# Altium schematic sheet 04_gap_interface.SchDoc(04_gap_interface)
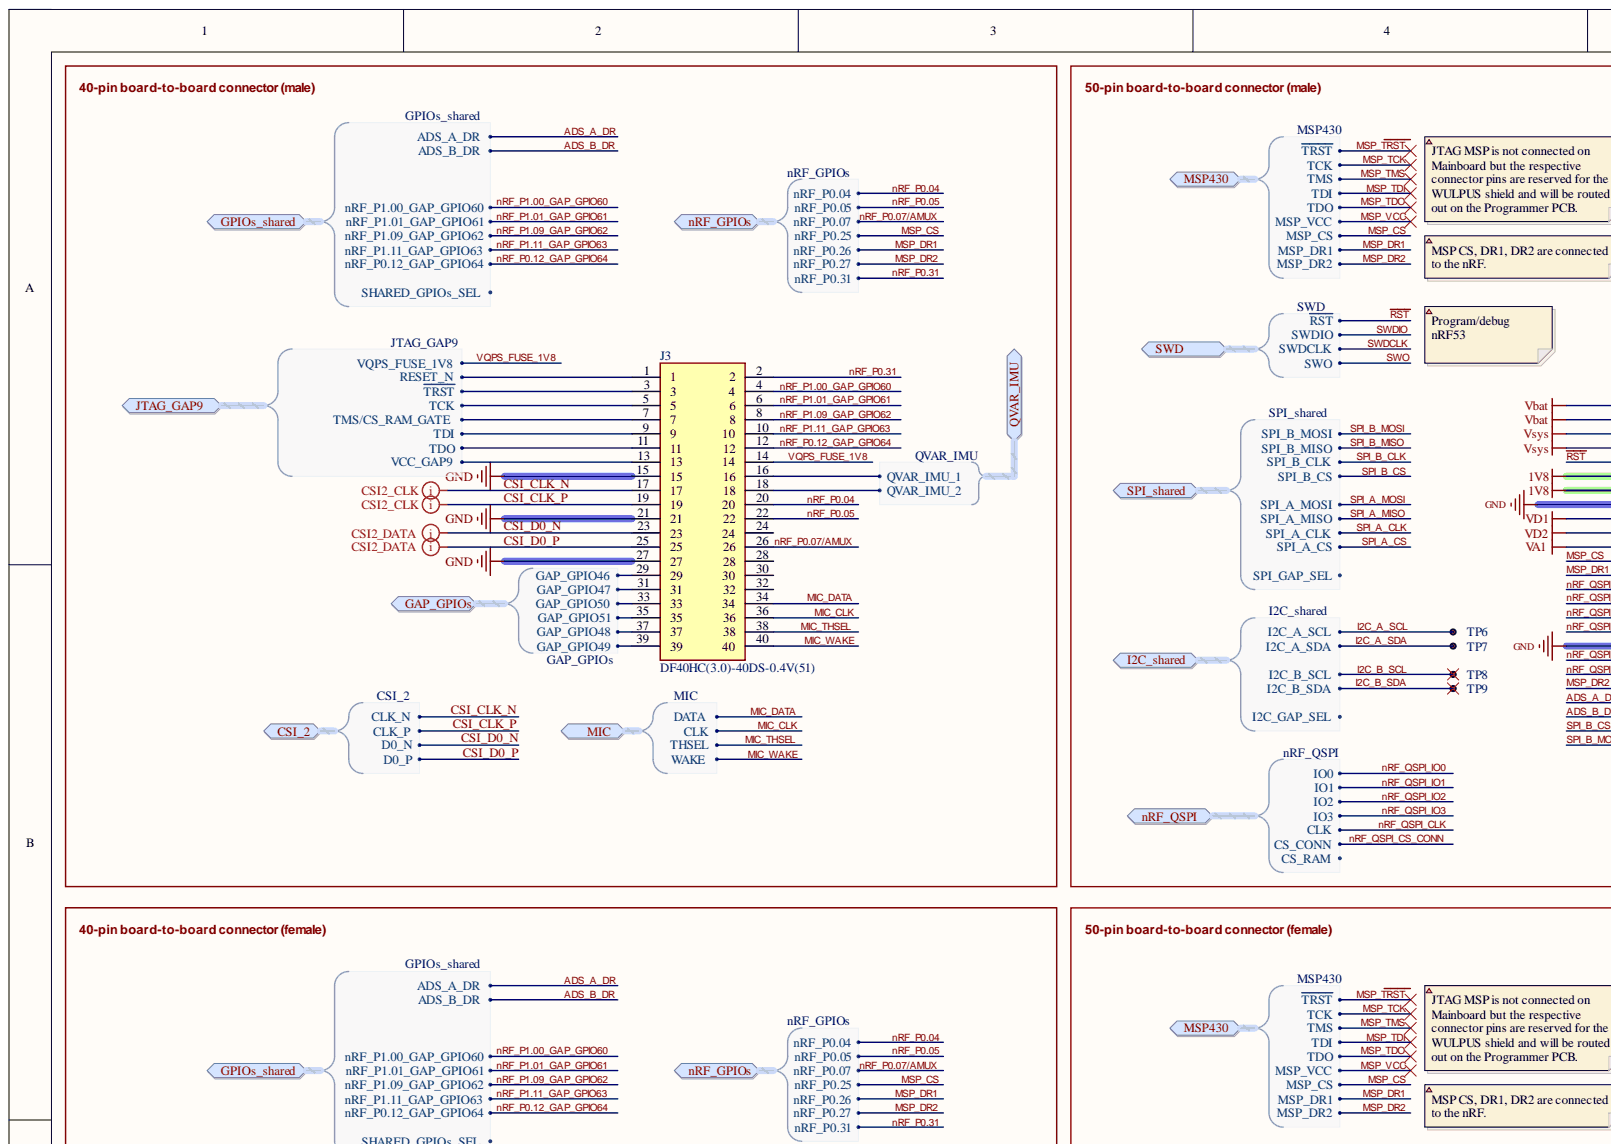
[diagram p0: 04_gap_interface.SchDoc, top left]
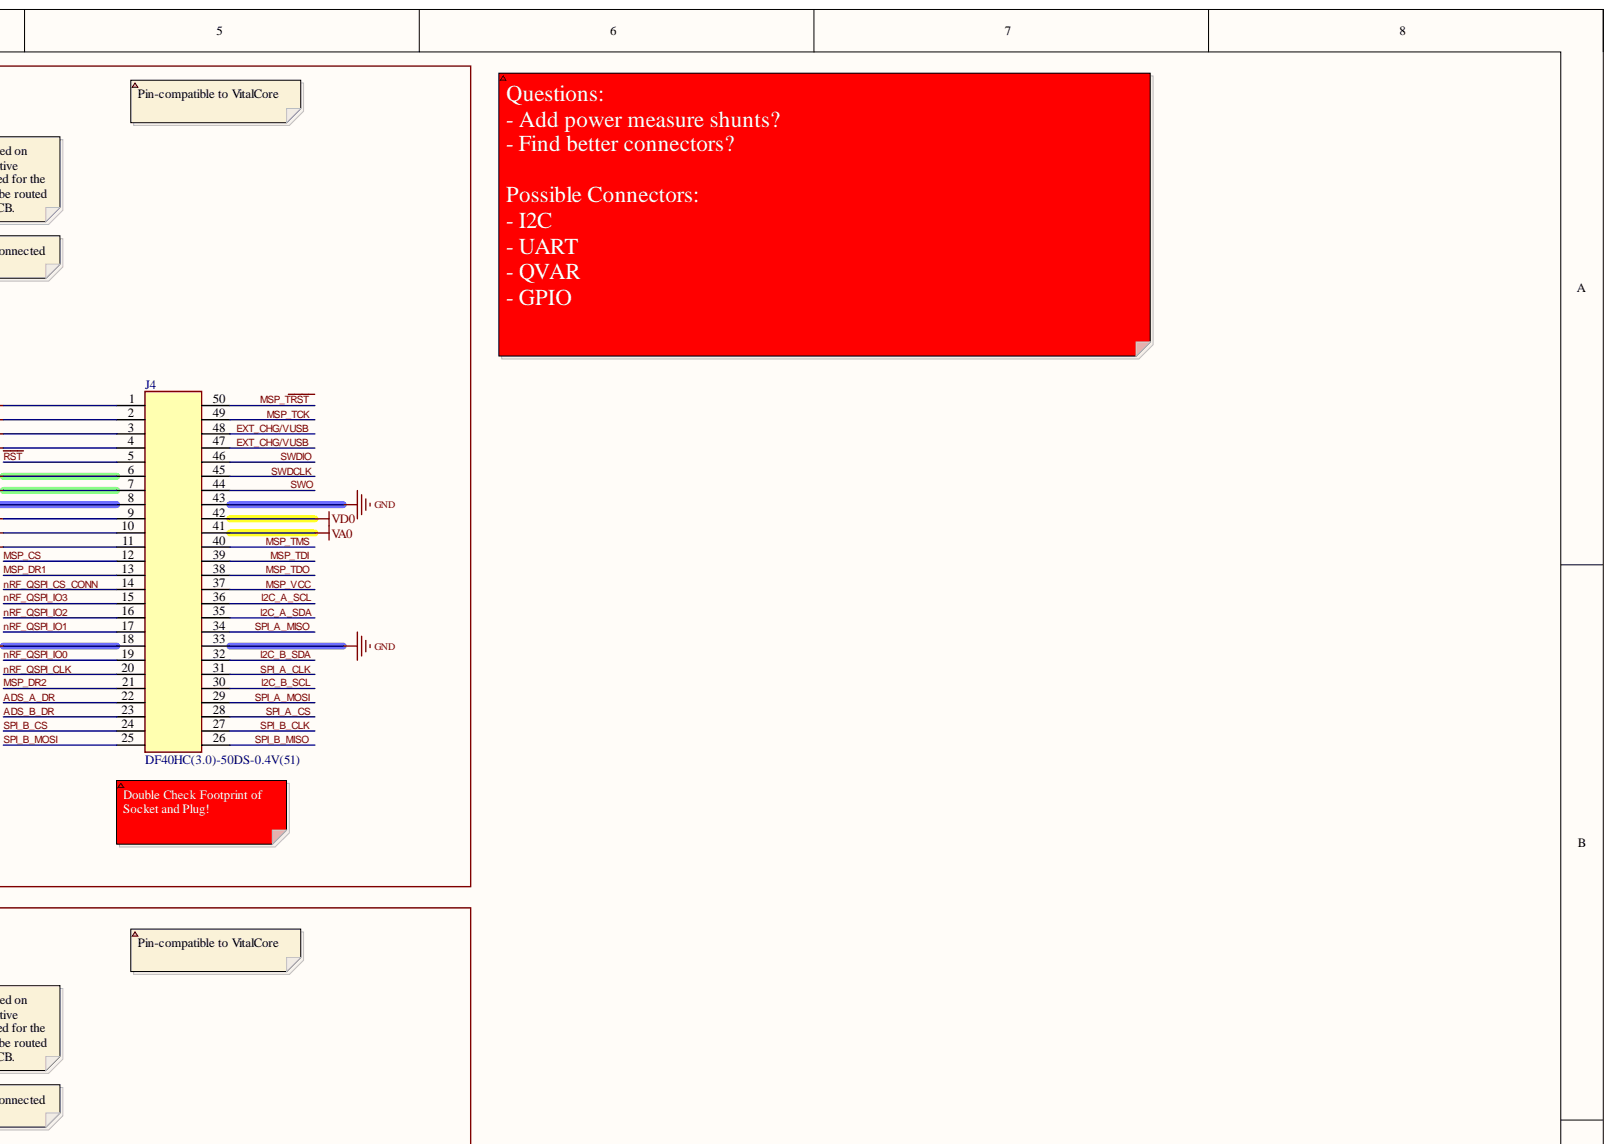
[diagram p1: 04_gap_interface.SchDoc, top right]
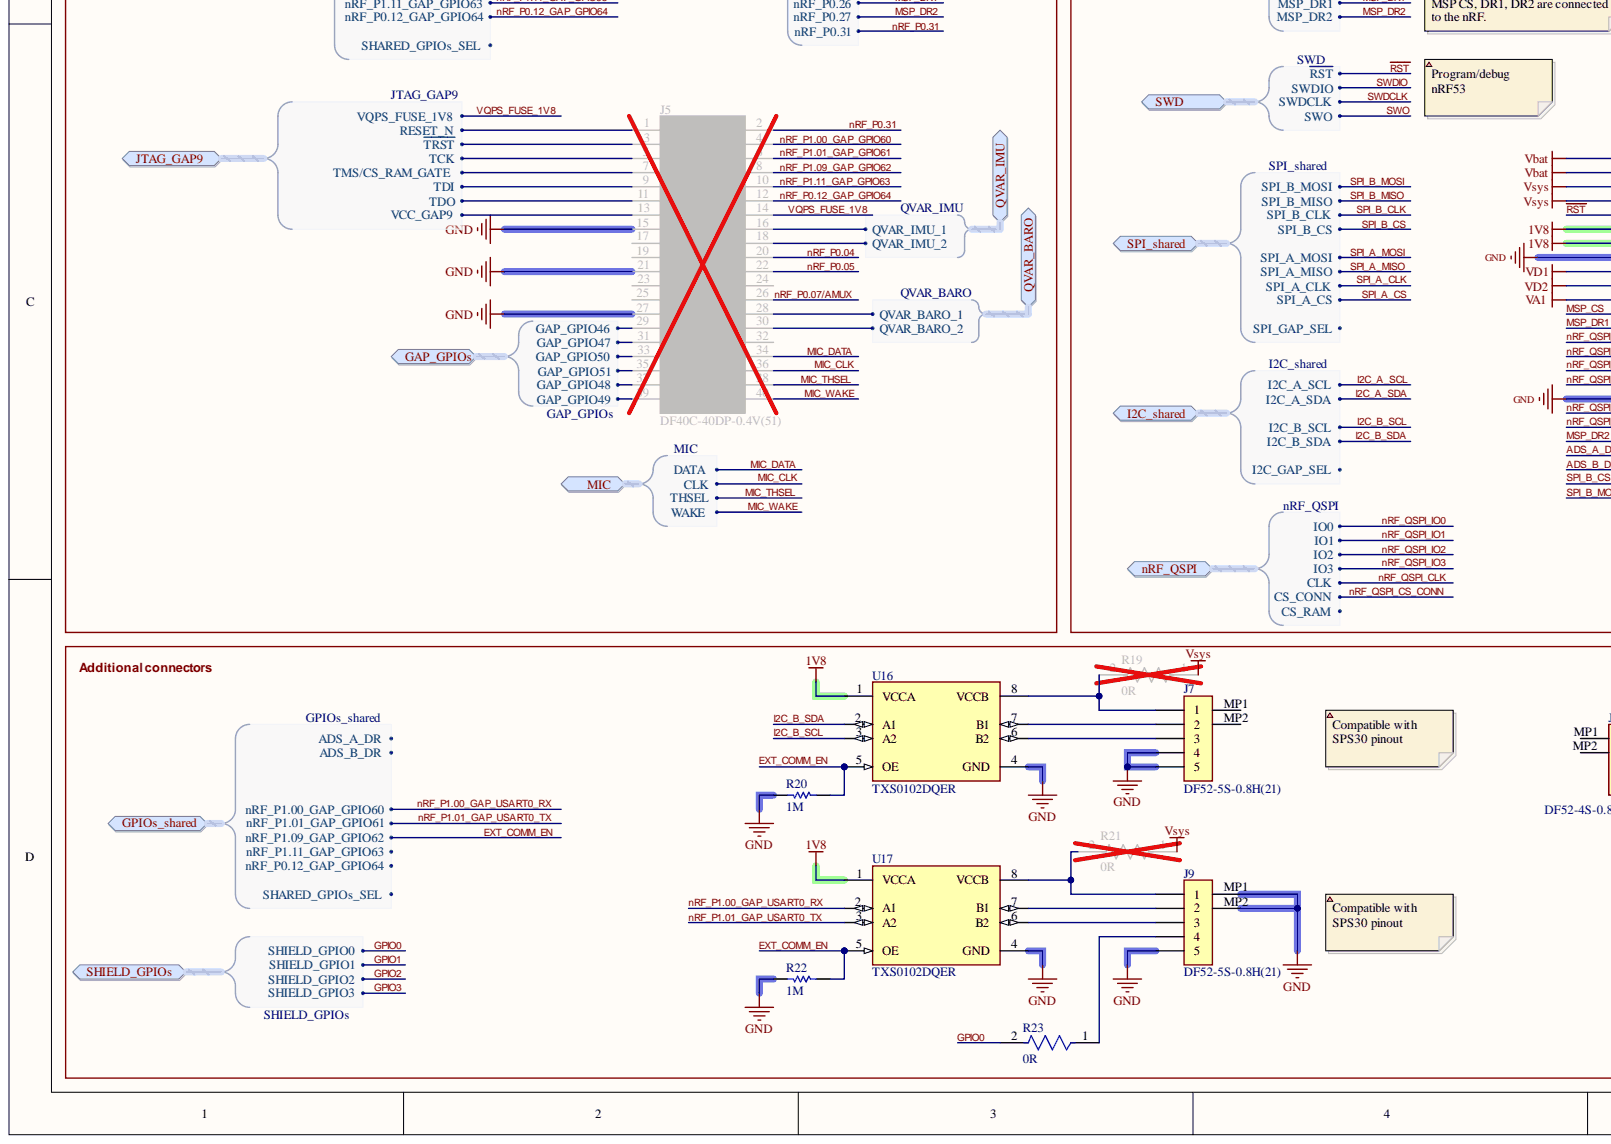
[diagram p2: 04_gap_interface.SchDoc, bottom left]
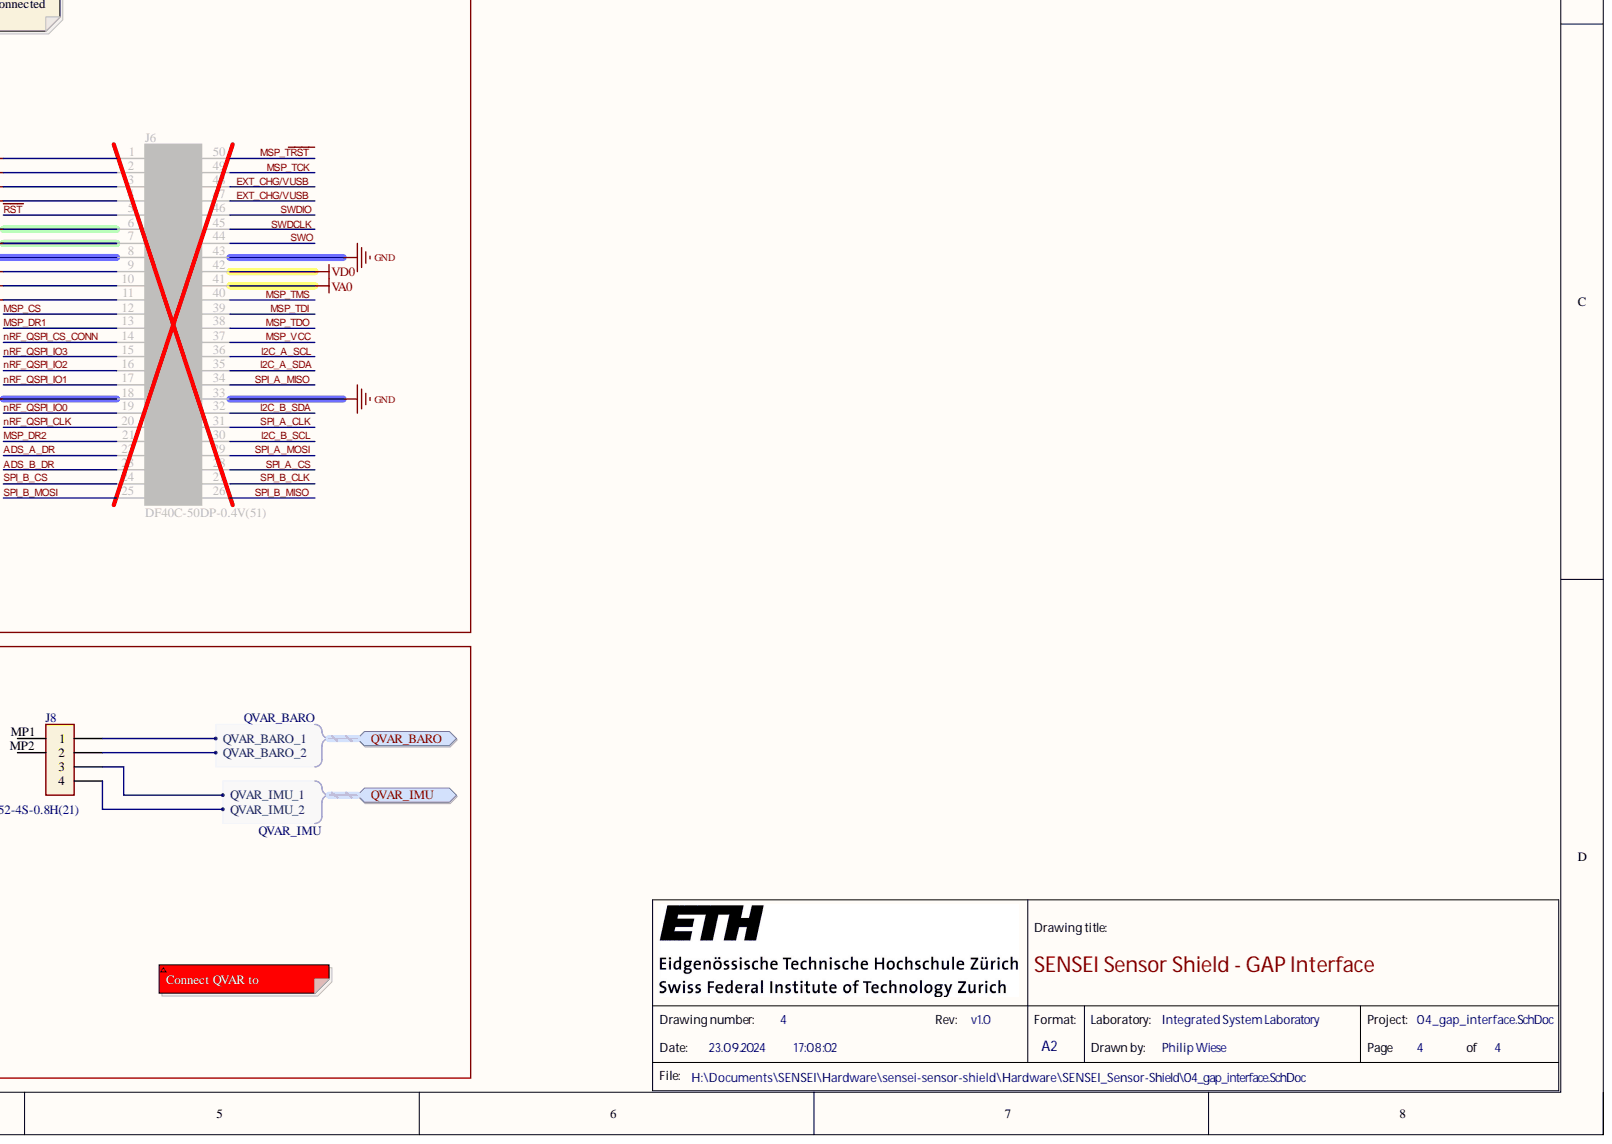
[diagram p3: 04_gap_interface.SchDoc, bottom right]

## Parts
J3  DF40HC(3.0)-40DS-0.4V(51)  [DF40HC3040DS04V51]
J4  DF40HC(3.0)-50DS-0.4V(51)  [DF40HC3050DS04V51]
J5  DF40C-40DP-0.4V(51)  [FP-DF40C-40DP-0_4V_51-MFG]
J6  DF40C-50DP-0.4V(51)  [CONN_COMBI_50P]
J7  DF52-5S-0.8H(21)  [DF525S08H21]
J8  DF52-4S-0.8H(21)  [DF524S08H21]
J9  DF52-5S-0.8H(21)  [DF525S08H21]
R19  Resistance: 0R  [FP-RMCF0402-IPC_A]  (hidden comment: RMCF0402ZT0R00)
R20  value 1M  Resistance: 1M  [RESC0201(0603)_L]  (hidden comment: ERJ-1GNJ105C)
R21  Resistance: 0R  [FP-RMCF0402-IPC_A]  (hidden comment: RMCF0402ZT0R00)
R22  value 1M  Resistance: 1M  [RESC0201(0603)_L]  (hidden comment: ERJ-1GNJ105C)
R23  Resistance: 0R  [FP-RMCF0402-IPC_A]  (hidden comment: RMCF0402ZT0R00)
TP6  Test Pad  [Pad-0.6mm]  (value not drawn)  (no BOM)
TP7  Test Pad  [Pad-0.6mm]  (value not drawn)  (no BOM)
TP8  Test Pad  [Pad-0.6mm]  (value not drawn)  (no BOM)
TP9  Test Pad  [Pad-0.6mm]  (value not drawn)  (no BOM)
U16  TXS0102DQER  [FP-DQE0008A-MFG]
U17  TXS0102DQER  [FP-DQE0008A-MFG]

## Ports
CSI_2, CSI_2.CLK_N, CSI_2.CLK_P, CSI_2.D0_N, CSI_2.D0_P, GAP_GPIOs, GAP_GPIOs.GAP_GPIO46, GAP_GPIOs.GAP_GPIO47, GAP_GPIOs.GAP_GPIO48, GAP_GPIOs.GAP_GPIO49, GAP_GPIOs.GAP_GPIO50, GAP_GPIOs.GAP_GPIO51, GPIOs_shared, GPIOs_shared.ADS_A_DR, GPIOs_shared.ADS_B_DR, GPIOs_shared.nRF_P0.12_GAP_GPIO64, GPIOs_shared.nRF_P1.00_GAP_GPIO60, GPIOs_shared.nRF_P1.01_GAP_GPIO61, GPIOs_shared.nRF_P1.09_GAP_GPIO62, GPIOs_shared.nRF_P1.11_GAP_GPIO63, GPIOs_shared.SHARED_GPIOs_SEL, I2C_shared, I2C_shared.I2C_A_SCL, I2C_shared.I2C_A_SDA, I2C_shared.I2C_B_SCL, I2C_shared.I2C_B_SDA, I2C_shared.I2C_GAP_SEL, JTAG_GAP9, JTAG_GAP9.RESET_N, JTAG_GAP9.TCK, JTAG_GAP9.TDI, JTAG_GAP9.TDO, JTAG_GAP9.TMS/CS_RAM_GATE, JTAG_GAP9.VCC_GAP9, JTAG_GAP9.VQPS_FUSE_1V8, JTAG_GAP9.~{TRST}, MIC, MIC.CLK, MIC.DATA, MIC.THSEL, MIC.WAKE, MSP430, MSP430.MSP_CS, MSP430.MSP_DR1, MSP430.MSP_DR2, MSP430.MSP_VCC, MSP430.TCK, MSP430.TDI, MSP430.TDO, MSP430.TMS, MSP430.~{TRST}, nRF_GPIOs, nRF_GPIOs.nRF_P0.04, nRF_GPIOs.nRF_P0.05, nRF_GPIOs.nRF_P0.07, nRF_GPIOs.nRF_P0.25, nRF_GPIOs.nRF_P0.26, nRF_GPIOs.nRF_P0.27, nRF_GPIOs.nRF_P0.31, nRF_QSPI, nRF_QSPI.CLK, nRF_QSPI.CS_CONN, nRF_QSPI.CS_RAM, nRF_QSPI.IO0, nRF_QSPI.IO1, nRF_QSPI.IO2, nRF_QSPI.IO3, QVAR_BARO, QVAR_BARO.QVAR_BARO_1, QVAR_BARO.QVAR_BARO_2, QVAR_IMU, QVAR_IMU.QVAR_IMU_1, QVAR_IMU.QVAR_IMU_2, SHIELD_GPIOs, SHIELD_GPIOs.SHIELD_GPIO0, SHIELD_GPIOs.SHIELD_GPIO1, SHIELD_GPIOs.SHIELD_GPIO2, SHIELD_GPIOs.SHIELD_GPIO3, SPI_shared, SPI_shared.SPI_A_CLK, SPI_shared.SPI_A_CS, SPI_shared.SPI_A_MISO, SPI_shared.SPI_A_MOSI, SPI_shared.SPI_B_CLK, SPI_shared.SPI_B_CS, SPI_shared.SPI_B_MISO, SPI_shared.SPI_B_MOSI, SPI_shared.SPI_GAP_SEL, SWD, SWD.SWDCLK, SWD.SWDIO, SWD.SWO, SWD.~{RST}

## Nets
1V8: J4.6 J4.7 J6.6 J6.7 U16.1(VCCA) U17.1(VCCA)
GND: J3.15 J3.21 J3.27 J4.8 J4.18 J4.33 J4.43 J5.15 J5.21 J5.27 J6.8 J6.18 J6.33 J6.43 J7.4 J7.5 J9.5 J9.MP1 J9.MP2 R20.2 R22.2 U16.4(GND) U17.4(GND)
VA0: J4.41 J6.41
VA1: J4.11 J6.11
Vbat: J4.1 J4.2 J6.1 J6.2
VD0: J4.42 J6.42
VD1: J4.9 J6.9
VD2: J4.10 J6.10
Vsys: J4.3 J4.4 J6.3 J6.4 R19.1 R21.1
ADS_A_DR: J4.22 J6.22 ; port GPIOs_shared
ADS_B_DR: J4.23 J6.23 ; port GPIOs_shared
CSI_CLK_N: J3.17 ; port CSI_2
CSI_CLK_P: J3.19 ; port CSI_2
CSI_D0_N: J3.23 ; port CSI_2
CSI_D0_P: J3.25 ; port CSI_2
EXT_CHG/VUSB: J4.47 J4.48 J6.47 J6.48
EXT_COMM_EN: J3.8 J5.8 R20.1 R22.1 U16.5(OE) U17.5(OE) ; port GPIOs_shared
GPIO0: R23.2 ; port SHIELD_GPIOs
I2C_A_SCL: J4.36 J6.36 TP6.1 ; port I2C_shared
I2C_A_SDA: J4.35 J6.35 TP7.1 ; port I2C_shared
I2C_B_SCL: J4.30 J6.30 TP8.1 U16.3(A2) ; port I2C_shared
I2C_B_SDA: J4.32 J6.32 TP9.1 U16.2(A1) ; port I2C_shared
MIC_CLK: J3.36 J5.36 ; port MIC
MIC_DATA: J3.34 J5.34 ; port MIC
MIC_THSEL: J3.38 J5.38 ; port MIC
MIC_WAKE: J3.40 J5.40 ; port MIC
MSP_CS: J4.12 J6.12 ; port MSP430, port nRF_GPIOs
MSP_DR1: J4.13 J6.13 ; port MSP430, port nRF_GPIOs
MSP_DR2: J4.21 J6.21 ; port MSP430, port nRF_GPIOs
MSP_~{TRST}: J4.50 J6.50 ; port MSP430
MSP_TCK: J4.49 J6.49 ; port MSP430
MSP_TDI: J4.39 J6.39 ; port MSP430
MSP_TDO: J4.38 J6.38 ; port MSP430
MSP_TMS: J4.40 J6.40 ; port MSP430
MSP_VCC: J4.37 J6.37 ; port MSP430
nRF_P0.04: J3.20 J5.20 ; port nRF_GPIOs
nRF_P0.05: J3.22 J5.22 ; port nRF_GPIOs
nRF_P0.07/AMUX: J3.26 J5.26 ; port nRF_GPIOs
nRF_P0.12_GAP_GPIO64: J3.12 J5.12 ; port GPIOs_shared
nRF_P0.31: J3.2 J5.2 ; port nRF_GPIOs
nRF_P1.00_GAP_GPIO60: J3.4 J5.4 U17.2(A1) ; port GPIOs_shared
nRF_P1.01_GAP_GPIO61: J3.6 J5.6 U17.3(A2) ; port GPIOs_shared
nRF_P1.11_GAP_GPIO63: J3.10 J5.10 ; port GPIOs_shared
nRF_QSPI_CLK: J4.20 J6.20 ; port nRF_QSPI
nRF_QSPI_CS_CONN: J4.14 J6.14 ; port nRF_QSPI
nRF_QSPI_IO0: J4.19 J6.19 ; port nRF_QSPI
nRF_QSPI_IO1: J4.17 J6.17 ; port nRF_QSPI
nRF_QSPI_IO2: J4.16 J6.16 ; port nRF_QSPI
nRF_QSPI_IO3: J4.15 J6.15 ; port nRF_QSPI
~{RST}: J4.5 J6.5 ; port SWD
SPI_A_CLK: J4.31 J6.31 ; port SPI_shared
SPI_A_CS: J4.28 J6.28 ; port SPI_shared
SPI_A_MISO: J4.34 J6.34 ; port SPI_shared
SPI_A_MOSI: J4.29 J6.29 ; port SPI_shared
SPI_B_CLK: J4.27 J6.27 ; port SPI_shared
SPI_B_CS: J4.24 J6.24 ; port SPI_shared
SPI_B_MISO: J4.26 J6.26 ; port SPI_shared
SPI_B_MOSI: J4.25 J6.25 ; port SPI_shared
SWDCLK: J4.45 J6.45 ; port SWD
SWDIO: J4.46 J6.46 ; port SWD
SWO: J4.44 J6.44 ; port SWD
VQPS_FUSE_1V8: J3.14 J5.14 ; port JTAG_GAP9
NetJ3_1: J3.1 J5.1 ; port JTAG_GAP9
NetJ3_3: J3.3 J5.3 ; port JTAG_GAP9
NetJ3_5: J3.5 J5.5 ; port JTAG_GAP9
NetJ3_7: J3.7 J5.7 ; port JTAG_GAP9
NetJ3_9: J3.9 J5.9 ; port JTAG_GAP9
NetJ3_11: J3.11 J5.11 ; port JTAG_GAP9
NetJ3_13: J3.13 J5.13 ; port JTAG_GAP9
NetJ3_16: J3.16 J5.16 J8.3 ; port QVAR_IMU
NetJ3_18: J3.18 J5.18 J8.4 ; port QVAR_IMU
NetJ3_29: J3.29 J5.29 ; port GAP_GPIOs
NetJ3_31: J3.31 J5.31 ; port GAP_GPIOs
NetJ3_33: J3.33 J5.33 ; port GAP_GPIOs
NetJ3_35: J3.35 J5.35 ; port GAP_GPIOs
NetJ3_37: J3.37 J5.37 ; port GAP_GPIOs
NetJ3_39: J3.39 J5.39 ; port GAP_GPIOs
NetJ5_28: J5.28 J8.1 ; port QVAR_BARO
NetJ5_30: J5.30 J8.2 ; port QVAR_BARO
NetJ7_1: J7.1 R19.2 U16.8(VCCB)
NetJ7_2: J7.2 U16.7(B1)
NetJ7_3: J7.3 U16.6(B2)
NetJ9_1: J9.1 R21.2 U17.8(VCCB)
NetJ9_2: J9.2 U17.7(B1)
NetJ9_3: J9.3 U17.6(B2)
NetJ9_4: J9.4 R23.1
GPIO1: ; port SHIELD_GPIOs
GPIO2: ; port SHIELD_GPIOs
GPIO3: ; port SHIELD_GPIOs
N00007: ; port I2C_shared
N00016: ; port GPIOs_shared
N00018: ; port SPI_shared
N00019: ; port nRF_QSPI

Not connected: J3 24 28 30 32; J5 17 19 23 24 25 32; J7 MP1 MP2; J8 MP1 MP2

## Library data of the parts
J3 = DF40HC(3.0)-40DS-0.4V(51): 40 Position 0.4mm Pitch Dual Row Vertical Surface Mount (SMT) Pin Socket, 3.0mm Stacking Height; System: Connector; Availability: Unavailable; Component Kind: Standard; Library Name: EEG_SchLib.SchLib; MP: DF40HC(3.0)-40DS-0.4V(51); Package: None; Price: None
J4 = DF40HC(3.0)-50DS-0.4V(51): 50 Position 0.4mm Pitch Dual Row Vertical Surface Mount (SMT) Pin Socket, 3.0mm Stacking Height; Mfr_Name: Hirose; Component Kind: Standard; Library Name: EEG_SchLib.SchLib; Manufacturer_Part_Number: DF40HC(3.0)-50DS-0.4V(51); RefDes: RefDes; Type: DEV; Copyright: Copyright (C) 2023 Ultra Librarian. All rights reserved.
J5 = DF40C-40DP-0.4V(51): 50 Position 0.4mm Pitch Dual Row Vertical Surface Mount (SMT) Receptacle; Connector Type: Plug; Current Rating: 300mA; Gender: Male; Mated Stacking Heights: 4mm; Number of Contacts: 40; System: Connector; Voltage Rating: 30V; Component Kind: Standard; Contact Material: Bronze; Contact Plating: Gold; Housing Material: Polymer; Lead Free: Lead Free; Library Name: EEG_SchLib.SchLib; Max Operating Temperature: 85°C; Min Operating Temperature: -35°C; Mount: Surface Mount; Number of Positions: 40; Number of Rows: 2; Orientation: Straight; Package Quantity: 1; Packaging: Tape and Reel; Pitch: 0.4mm; REACH SVHC: No SVHC; Termination: SMD/SMT
J6 = DF40C-50DP-0.4V(51): 50 Position 0.4mm Pitch Dual Row Vertical Surface Mount (SMT) Receptacle,; Mfr_Name: Hirose; System: Connector; Component Kind: Standard; Library Name: EEG_SchLib.SchLib; Manufacturer_Part_Number: DF40C-50DP-0.4V(51); RefDes: RefDes; Type: DEV; Copyright: Copyright (C) 2023 Ultra Librarian. All rights reserved.
J7 J9 = DF52-5S-0.8H(21): Hirose DF52 52 Series 0.8mm Pitch 5 Way Right Angle Female FPC Connector; Geometry.Height: 1mm; Component Kind: Standard; Datasheet Link: https://www.hirose.com/product/en/products/DF52/DF52-5S-0.8H%2821%29/; Library Name: DF525S08H21.SchLib; Manufacturer_Name: Hirose; Manufacturer_Part_Number: DF52-5S-0.8H(21); Mouser Part Number: 798-DF52-5S-0.8H21; Mouser Price/Stock: https://www.mouser.co.uk/ProductDetail/Hirose-Connector/DF52-5S-0.8H21?qs=%2FKR40Cd6GUoIdnvQLqKyqQ%3D%3D
J8 = DF52-4S-0.8H(21): Hirose DF52 52 Series 0.8mm Pitch 4 Way Right Angle Female FPC Connector; Geometry.Height: 1mm; Component Kind: Standard; Datasheet Link: https://www.hirose.com/product/en/products/DF52/DF52-5S-0.8H%2821%29/; Library Name: DF52.SchLib; Manufacturer_Name: Hirose; Manufacturer_Part_Number: DF52-4S-0.8H(21); Mouser Part Number: 798-DF52-4S-0.8H21; Mouser Price/Stock: https://www.mouser.co.uk/ProductDetail/Hirose-Connector/DF52-4S-0.8H21?qs=%2FKR40Cd6GUqI6DynPcUU4w%3D%3D; Supplier 1: Rs; Supplier Part Number 1: 1620926
R19 R21 R23 = CMP-26527-007617-1: RES 0 OHM JUMPER 1/16W 0402; Current Rating: 1A; Max Power Dissipation: 63mW; Working Voltage: 50V; Case Code (Imperial): 0402; Case Code (Metric): 1005; Case/Package: 0402; Component Kind: Standard; Construction: Rectangular; Contact Plating: Tin; Depth: 0.5mm; Features: Automotive AEC-Q200; Height: 0.016inch; Lead Free: Lead Free; Length: 0.039inch; Library Name: Altium Content Vault; Max Operating Temperature: 155°C; Military Standard: Not; Min Operating Temperature: -55°C; Mount: Surface Mount; Number of Terminations: 2; Packaging: Tape and Reel; Power Rating: 63mW; Radiation Hardening: No; Resistor Type: Thick Film; RoHS Compliant: Yes; Series: RMCF; Technology: THICK FILM; Width: 0.02inch
R20 R22 = CMP-1010-00146-1: 1M 0.05W 5% 0201 (0603 Metric)  SMD; Case-EIA: 0201; Case-Metric: 0603; Component Kind: Standard; Library Name: Altium Content Vault; Power: 0.05 W; Technology: SMT; Tolerance: 5 %
TP6-TP9 = CMP-00224-2: 0.6mm SMT Test Pad; Library Name: ETHZ Vault; Mounting Technology: SMT
U16 U17 = CMP-04899-000003-1: IC TRNSLTR BIDIRECTIONAL 8X2SON; Manufacturer: Texas Instruments; Data Rate: 24Mbits/s; Logic Function Desc: Non-Inverting; Max Junction Temp: +150°C; Max Supply Voltage: 5.5V; Min Supply Voltage: 1.65V; Nominal Supply Current: 14.4uA; Number Of Circuits: 1; Number Of Inputs: 2; Propagation Delay: 28ns; Standoff Height: 0mm; V A Max: 3.6V; V A Min: 1.25V; V B Min: 2.3V; Ambient Temperature Range High: +85°C; Ambient Temperature Range Low: -40°C; Automotive: No; Category: IC; Component Kind: Standard; ComponentLink2Description: Datasheet; ComponentLink2URL: http://www.ti.com/lit/ds/symlink/txs0102.pdf; Device Class L1: Integrated Circuits (ICs); Device Class L2: Logic ICs; Device Class L3: Voltage Level Shifters; Digikey Description: IC TRNSLTR BIDIRECTIONAL 8X2SON; Height: 0.4mm; Ipc Land Pattern Name: DFN140X100X37-8; Lead Free: Yes; Library Name: Altium Content Vault; Manufacturer Part Number: TXS0102DQER; Mouser Description: Translation - Voltage Levels 2B Bidirec Vltg-Lev Translator; Number Of Outputs: 2; Octopart: https://octopart.com/txs0102dqer-texas+instruments-19973770; Package: DQE0008A; RoHS: TRUE; Temperature: -40°C to +85°C; Temperature Range High: +85°C; Temperature Range Low: -40°C; V B Max: 5.5V
